# Altium schematic sheet BACKPMAN30_Charger.SchDoc
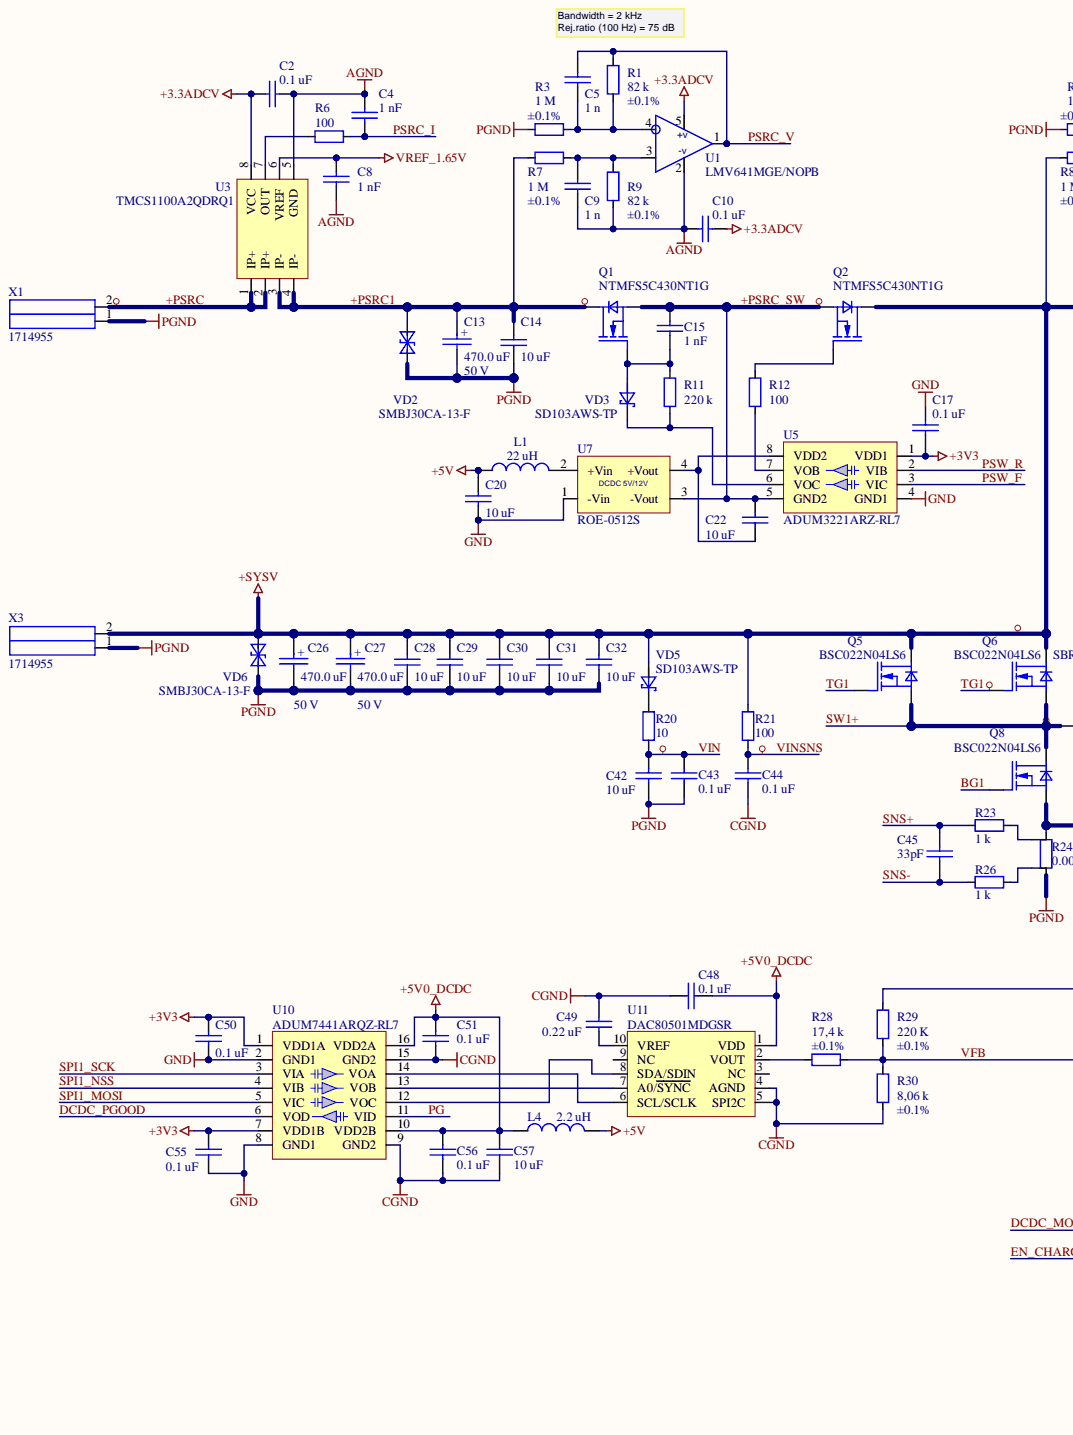
[diagram p0: BACKPMAN30_Charger.SchDoc, left]
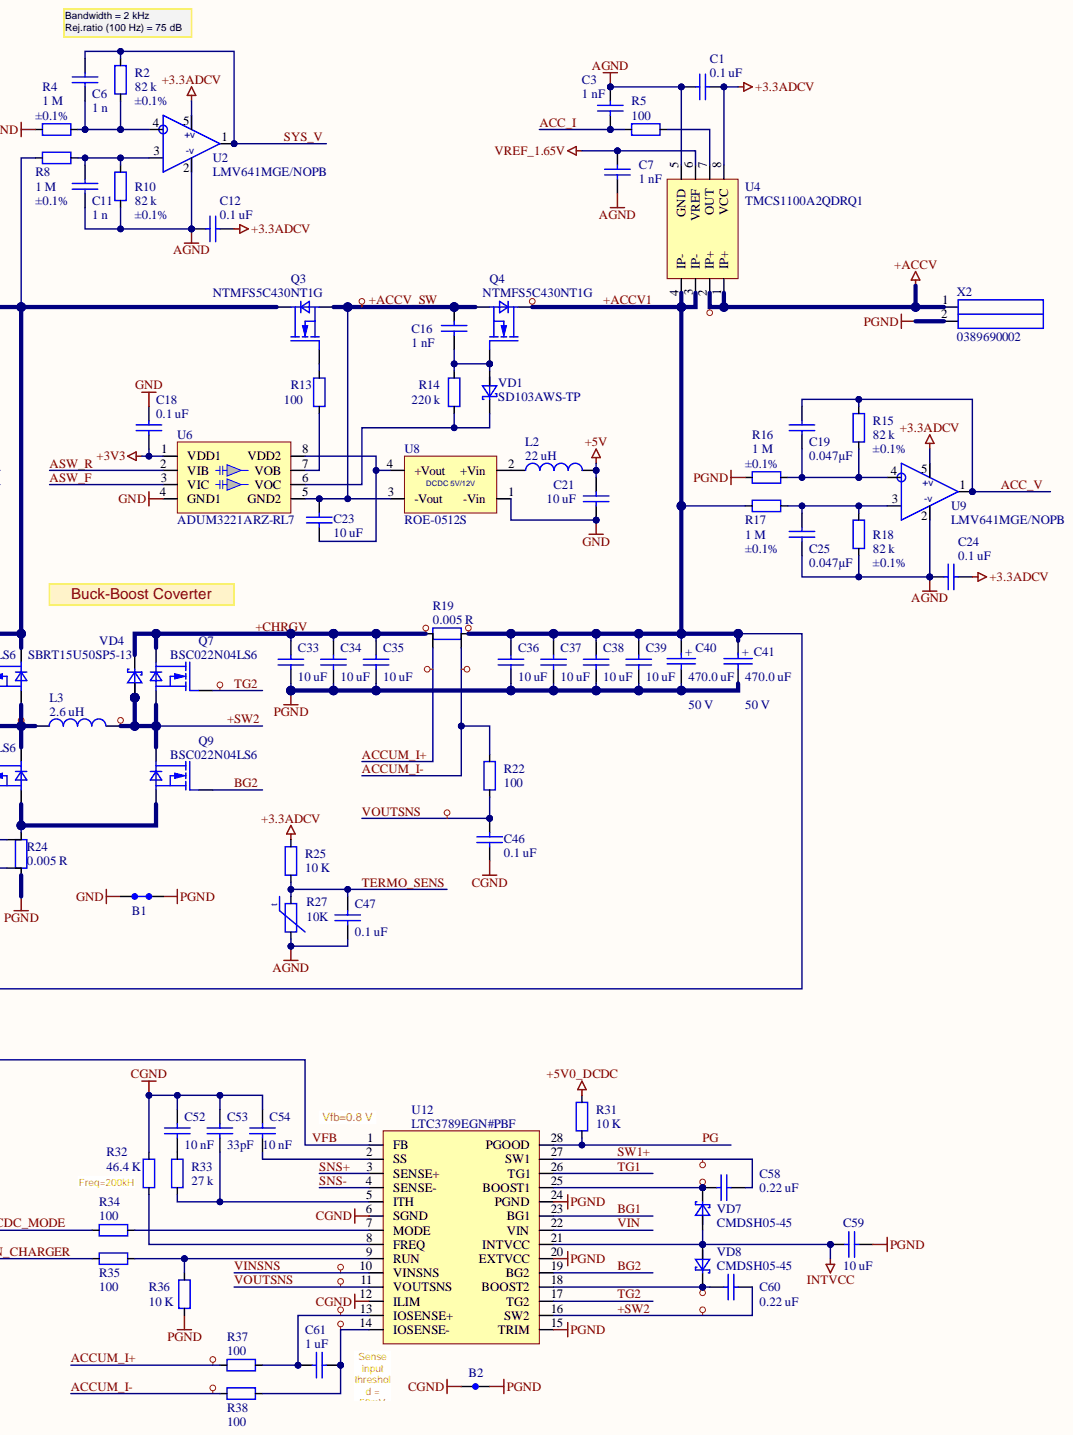
[diagram p1: BACKPMAN30_Charger.SchDoc, right]

## Parts
B1  Net tie W10  [Net tee W 10 mm]  (value not drawn)
B2  Net tie 0.3mm  [Net tee 0.3 mm]  (value not drawn)
C1  value 0.1 uF  [1608[0603]]  (hidden comment: 0603B104K500CT)
C2  value 0.1 uF  [1608[0603]]  (hidden comment: 0603B104K500CT)
C3  value 1 nF  [0603 CAP]  (hidden comment: Capacitor)
C4  value 1 nF  [0603 CAP]  (hidden comment: Capacitor)
C5  value 1 n  [1608[0603]]  (hidden comment: 06033A102FAT2A)
C6  value 1 n  [1608[0603]]  (hidden comment: 06033A102FAT2A)
C7  value 1 nF  [0603 CAP]  (hidden comment: Capacitor)
C8  value 1 nF  [0603 CAP]  (hidden comment: Capacitor)
C9  value 1 n  [1608[0603]]  (hidden comment: 06033A102FAT2A)
C10  value 0.1 uF  [1608[0603]]  (hidden comment: 0603B104K500CT)
C11  value 1 n  [1608[0603]]  (hidden comment: 06033A102FAT2A)
C12  value 0.1 uF  [1608[0603]]  (hidden comment: 0603B104K500CT)
C13  value 470.0 uF  Voltage: 50 V  [Through Hole 12.5/20/5]  (hidden comment: EEU-FM1H471)
C14  value 10 uF  [3225[1210]]  (hidden comment: GMC32X7R106K50NT)
C15  value 1 nF  [0603 CAP]  (hidden comment: Capacitor)
C16  value 1 nF  [0603 CAP]  (hidden comment: Capacitor)
C17  value 0.1 uF  [1608[0603]]  (hidden comment: CL10B104KA8NNND)
C18  value 0.1 uF  [1608[0603]]  (hidden comment: CL10B104KA8NNND)
C19  value 0.047µF  [0603 CAP]  (hidden comment: Capacitor)
C20  value 10 uF  [3225[1210]]  (hidden comment: GMC32X7R106K50NT)
C21  value 10 uF  [3225[1210]]  (hidden comment: GMC32X7R106K50NT)
C22  value 10 uF  [3225[1210]]  (hidden comment: GMC32X7R106K50NT)
C23  value 10 uF  [3225[1210]]  (hidden comment: GMC32X7R106K50NT)
C24  value 0.1 uF  [1608[0603]]  (hidden comment: 0603B104K500CT)
C25  value 0.047µF  [0603 CAP]  (hidden comment: Capacitor)
C26  value 470.0 uF  Voltage: 50 V  [Through Hole 12.5/20/5]  (hidden comment: EEU-FM1H471)
C27  value 470.0 uF  Voltage: 50 V  [Through Hole 12.5/20/5]  (hidden comment: EEU-FM1H471)
C28  value 10 uF  [3225[1210]]  (hidden comment: GMC32X7R106K50NT)
C29  value 10 uF  [3225[1210]]  (hidden comment: GMC32X7R106K50NT)
C30  value 10 uF  [3225[1210]]  (hidden comment: GMC32X7R106K50NT)
C31  value 10 uF  [3225[1210]]  (hidden comment: GMC32X7R106K50NT)
C32  value 10 uF  [3225[1210]]  (hidden comment: GMC32X7R106K50NT)
C33  value 10 uF  [3225[1210]]  (hidden comment: GMC32X7R106K50NT)
C34  value 10 uF  [3225[1210]]  (hidden comment: GMC32X7R106K50NT)
C35  value 10 uF  [3225[1210]]  (hidden comment: GMC32X7R106K50NT)
C36  value 10 uF  [3225[1210]]  (hidden comment: GMC32X7R106K50NT)
C37  value 10 uF  [3225[1210]]  (hidden comment: GMC32X7R106K50NT)
C38  value 10 uF  [3225[1210]]  (hidden comment: GMC32X7R106K50NT)
C39  value 10 uF  [3225[1210]]  (hidden comment: GMC32X7R106K50NT)
C40  value 470.0 uF  Voltage: 50 V  [Through Hole 12.5/20/5]  (hidden comment: EEU-FM1H471)
C41  value 470.0 uF  Voltage: 50 V  [Through Hole 12.5/20/5]  (hidden comment: EEU-FM1H471)
C42  value 10 uF  [3225[1210]]  (hidden comment: GMC32X7R106K50NT)
C43  value 0.1 uF  [1608[0603]]  (hidden comment: 0603B104K500CT)
C44  value 0.1 uF  [1608[0603]]  (hidden comment: 0603B104K500CT)
C45  value 33pF  [0603 CAP]  (hidden comment: Capacitor)
C46  value 0.1 uF  [1608[0603]]  (hidden comment: 0603B104K500CT)
C47  value 0.1 uF  [1608[0603]]  (hidden comment: 0603B104K500CT)
C48  value 0.1 uF  [1608[0603]]  (hidden comment: 0603B104K500CT)
C49  value 0.22 uF  [1608[0603]]  (hidden comment: C1608X7R1H224K080AB)
C50  value 0.1 uF  [1608[0603]]  (hidden comment: CL10B104KA8NNND)
C51  value 0.1 uF  [1608[0603]]  (hidden comment: CL10B104KA8NNND)
C52  value 10 nF  [1608[0603]]  (hidden comment: GMC10X7R103M50NT)
C53  value 33pF  [0603 CAP]  (hidden comment: Capacitor)
C54  value 10 nF  [1608[0603]]  (hidden comment: GMC10X7R103M50NT)
C55  value 0.1 uF  [1608[0603]]  (hidden comment: CL10B104KA8NNND)
C56  value 0.1 uF  [1608[0603]]  (hidden comment: CL10B104KA8NNND)
C57  value 10 uF  [2012[0805]]  (hidden comment: GRM21BR61E106KA73L)
C58  value 0.22 uF  [1608[0603]]  (hidden comment: C1608X7R1H224K080AB)
C59  value 10 uF  [2012[0805]]  (hidden comment: GRM21BR61E106KA73L)
C60  value 0.22 uF  [1608[0603]]  (hidden comment: C1608X7R1H224K080AB)
C61  value 1 uF  [1608[0603]]  (hidden comment: C1608X5R1H105K080AB)
L1  value 22 uH  [2518]  (hidden comment: BRC2518T220K)
L2  value 22 uH  [2518]  (hidden comment: BRC2518T220K)
L3  value 2.6 uH  [7443556260   Wurth Elektronik]  (hidden comment: 7443556260)
L4  value 2.2 uH  [LSQPB3225]  (hidden comment: BRL3225T2R2M)
Q1  NTMFS5C430NT1G  [SO8FL Universal Footprint]
Q2  NTMFS5C430NT1G  [SO8FL Universal Footprint]
Q3  NTMFS5C430NT1G  [SO8FL Universal Footprint]
Q4  NTMFS5C430NT1G  [SO8FL Universal Footprint]
Q5  BSC022N04LS6  [SO8FL Universal Footprint]
Q6  BSC022N04LS6  [SO8FL Universal Footprint]
Q7  BSC022N04LS6  [SO8FL Universal Footprint]
Q8  BSC022N04LS6  [SO8FL Universal Footprint]
Q9  BSC022N04LS6  [SO8FL Universal Footprint]
R1  value 82 k  [0603]  (hidden comment: Resistor)
R2  value 82 k  [0603]  (hidden comment: Resistor)
R3  value 1 M  Tolerance: ±0.1%  [0805]  (hidden comment: RT0805BRE071ML)
R4  value 1 M  Tolerance: ±0.1%  [0805]  (hidden comment: RT0805BRE071ML)
R5  value 100  [0603]  (hidden comment: Resistor)
R6  value 100  [0603]  (hidden comment: Resistor)
R7  value 1 M  [0805]  (hidden comment: RT0805BRE071ML)
R8  value 1 M  [0805]  (hidden comment: RT0805BRE071ML)
R9  value 82 k  Tolerance: ±0.1%  [0603]  (hidden comment: Resistor)
R10  value 82 k  Tolerance: ±0.1%  [0603]  (hidden comment: Resistor)
R11  value 220 k  [0603]  (hidden comment: Resistor)
R12  value 100  [0603]  (hidden comment: Resistor)
R13  value 100  [0603]  (hidden comment: Resistor)
R14  value 220 k  [0603]  (hidden comment: Resistor)
R15  value 82 k  [0603]  (hidden comment: Resistor)
R16  value 1 M  Tolerance: ±0.1%  [0805]  (hidden comment: RT0805BRE071ML)
R17  value 1 M  [0805]  (hidden comment: RT0805BRE071ML)
R18  value 82 k  Tolerance: ±0.1%  [0603]  (hidden comment: Resistor)
R19  value 0.005 R  [FC4L110]  (hidden comment: FC4L110R005FER)
R20  value 10  [0805]  (hidden comment: Resistor)
R21  value 100  [0603]  (hidden comment: Resistor)
R22  value 100  [0603]  (hidden comment: Resistor)
R23  value 1 k  [0603]  (hidden comment: Resistor)
R24  value 0.005 R  [FC4L110]  (hidden comment: FC4L110R005FER)
R25  value 10 K  [0603]  (hidden comment: RMCF0603FT10K0)
R26  value 1 k  [0603]  (hidden comment: Resistor)
R27  value 10K  [0603]  (hidden comment: NTCG163JX103DTDS)
R28  value 17,4 k  Tolerance: ±0.1%  [0603]  (hidden comment: Resistor)
R29  value 220 K  Tolerance: ±0.1%  [0603]  (hidden comment: TNPW0603220KBEEA)
R30  value 8,06 k  Tolerance: ±0.1%  [0603]  (hidden comment: Resistor)
R31  value 10 K  [0603]  (hidden comment: RMCF0603FT10K0)
R32  value 46.4 K  [0603]  (hidden comment: RC0603FR-0746K4L)
R33  value 27 k  [0603]  (hidden comment: Resistor)
R34  value 100  [0603]  (hidden comment: Resistor)
R35  value 100  [0603]  (hidden comment: Resistor)
R36  value 10 K  [0603]  (hidden comment: RMCF0603FT10K0)
R37  value 100  [0603]  (hidden comment: Resistor)
R38  value 100  [0603]  (hidden comment: Resistor)
U1  LMV641MGE/NOPB  [SOT-353 ON Semi]
U2  LMV641MGE/NOPB  [SOT-353 ON Semi]
U3  TMCS1100A2QDRQ1  [8-SOIC Linear]
U4  TMCS1100A2QDRQ1  [8-SOIC Linear]
U5  ADUM3221ARZ-RL7  [8-SOIC Linear]
U6  ADUM3221ARZ-RL7  [8-SOIC Linear]
U7  ROE-0512S  [Recom 4-SIP module]
U8  ROE-0512S  [Recom 4-SIP module]
U9  LMV641MGE/NOPB  [SOT-353 ON Semi]
U10  ADUM7441ARQZ-RL7  [QSOP-16 AD]
U11  DAC80501MDGSR  [10-Lead Plastic MSOP Linear]
U12  LTC3789EGN#PBF  [28-Lead Plastic SSOP Linear]
VD1  SD103AWS-TP  [SOD323]
VD2  SMBJ30CA-13-F  [DO-214AA_SMBJ BI]
VD3  SD103AWS-TP  [SOD323]
VD4  SBRT15U50SP5-13  [PowerDI 5]
VD5  SD103AWS-TP  [SOD323]
VD6  SMBJ30CA-13-F  [DO-214AA_SMBJ BI]
VD7  PartName: CMDSH05-45  [SOD323]  (hidden comment: CMDSH05-45 TR PBFREE)
VD8  PartName: CMDSH05-45  [SOD323]  (hidden comment: CMDSH05-45 TR PBFREE)
X1  1714955  [MKDS5/2-6.35]
X2  0389690002  [Molex 389690002]
X3  1714955  [MKDS5/2-6.35]

## Nets
+3.3ADCV: C1.2 C2.2 C10.1 C12.1 C24.1 R25.2 U1.5(+v) U2.5(+v) U3.8(VCC) U4.8(VCC) U9.5(+v)
+3V3: C17.1 C18.1 C50.2 C55.2 U5.1(VDD1) U6.1(VDD1) U10.1(VDD1A) U10.7(VDD1B)
+5V: C20.1 C21.1 C48.1 C51.2 C56.2 C57.2 L1.1 L2.1 L4.1 L4.2 R31.1 U10.10(VDD2B) U10.16(VDD2A) U11.1(VDD)
+ACCV: U4.1(IP+) U4.2(IP+) X2.1
+SYSV: C26.1 C27.1 C28.1 C29.1 C30.1 C31.1 C32.1 Q2.3 Q3.3 Q5.3 Q6.3 R8.1 R21.2 VD5.1 VD6.2 X3.2
AGND: C1.1 C2.1 C3.2 C4.2 C7.1 C8.1 C9.2 C10.2 C11.2 C12.2 C24.2 C25.2 C47.2 R9.1 R10.1 R18.1 R27.1 U1.2(-v) U2.2(-v) U3.5(GND) U4.5(GND) U9.2(-v)
CGND: B2.2 C44.2 C46.2 C48.2 C49.1 C51.1 C52.1 C53.1 C54.1 C56.1 C57.1 R30.1 R32.1 U10.9(GND2) U10.15(GND2) U11.4(AGND) U11.5(SPI2C) U12.6(SGND) U12.12(ILIM)
GND: B1.1 C17.2 C18.2 C20.2 C21.2 C50.1 C55.1 U5.4(GND1) U6.4(GND1) U7.1(-Vin) U8.1(-Vin) U10.2(GND1) U10.8(GND1)
INTVCC: C59.1 U12.21(INTVCC) VD7.1 VD8.1
PGND: B1.2 B2.1 C13.2 C14.2 C26.2 C27.2 C28.2 C29.2 C30.2 C31.2 C32.2 C33.2 C34.2 C35.2 C36.2 C37.2 C38.2 C39.2 C40.2 C41.2 C42.2 C43.2 C59.2 R3.1 R4.1 R16.1 R24.2 R36.1 U12.15(TRIM) U12.20(EXTVCC) U12.24(PGND) VD2.1 VD6.1 X1.1 X2.2 X3.1
VREF_1.65V: C7.2 C8.2 U3.6(VREF) U4.6(VREF)
+ACCV1: C36.1 C37.1 C38.1 C39.1 C40.1 C41.1 Q4.3 R17.1 R19.2 R29.2 U4.3(IP-) U4.4(IP-)
+ACCV_SW: C16.2 C23.1 Q3.2 Q4.2 U6.5(GND2) U8.3(-Vout)
+CHRGV: C33.1 C34.1 C35.1 Q7.3 R19.1 VD4.3
+PSRC: U3.1(IP+) U3.2(IP+) X1.2
+PSRC1: C13.1 C14.1 Q1.3 R7.1 U3.3(IP-) U3.4(IP-) VD2.2
+PSRC_SW: C15.2 C22.1 Q1.2 Q2.2 U5.5(GND2) U7.3(-Vout)
+SW2: C60.2 L3.2 Q7.2 Q9.3 U12.16(SW2) VD4.1 VD4.2
ACC_I: C3.1 R5.1
ACC_V: C19.1 R15.2 U9.1
ACCUM_I+: R19.3 R37.2
ACCUM_I-: R19.4 R22.2 R38.2
ASW_F: U6.3(VIC)
ASW_R: U6.2(VIB)
BG1: Q8.1 U12.23(BG1)
BG2: Q9.1 U12.19(BG2)
DCDC_MODE: R34.2
DCDC_PGOOD: U10.6(VOD)
EN_CHARGER: R35.2
PG: R31.2 U10.11(VID) U12.28(PGOOD)
PSRC_I: C4.1 R6.1
PSRC_V: C5.1 R1.2 U1.1
PSW_F: U5.3(VIC)
PSW_R: U5.2(VIB)
SNS+: C45.1 R23.2 U12.3(SENSE+)
SNS-: C45.2 R26.2 U12.4(SENSE-)
SPI1_MOSI: U10.5(VIC)
SPI1_NSS: U10.4(VIB)
SPI1_SCK: U10.3(VIA)
SW1+: C58.2 L3.1 Q5.2 Q6.2 Q8.3 U12.27(SW1)
SYS_V: C6.1 R2.2 U2.1
TERMO_SENS: C47.1 R25.1 R27.2
TG1: Q5.1 Q6.1 U12.26(TG1)
TG2: Q7.1 U12.17(TG2)
VFB: R28.2 R29.1 R30.2 U12.1(FB)
VIN: C42.1 C43.1 R20.1 U12.22(VIN)
VINSNS: C44.1 R21.1 U12.10(VINSNS)
VOUTSNS: C46.1 R22.1 U12.11(VOUTSNS)
NetC5_2: C5.2 R1.1 R3.2 U1.4
NetC6_2: C6.2 R2.1 R4.2 U2.4
NetC9_1: C9.1 R7.2 R9.2 U1.3
NetC11_1: C11.1 R8.2 R10.2 U2.3
NetC15_1: C15.1 Q1.1 R11.2 VD3.1
NetC16_1: C16.1 Q4.1 R14.2 VD1.1
NetC19_2: C19.2 R15.1 R16.2 U9.4
NetC22_2: C22.2 U5.8(VDD2) U7.4(+Vout)
NetC23_2: C23.2 U6.8(VDD2) U8.4(+Vout)
NetC25_1: C25.1 R17.2 R18.2 U9.3
NetC49_2: C49.2 U11.10(VREF)
NetC52_2: C52.2 R33.2
NetC53_2: C53.2 R33.1 U12.5(ITH)
NetC54_2: C54.2 U12.2(SS)
NetC58_1: C58.1 U12.25(BOOST1) VD7.2
NetC60_1: C60.1 U12.18(BOOST2) VD8.2
NetC61_1: C61.1 R38.1 U12.14(IOSENSE-)
NetC61_2: C61.2 R37.1 U12.13(IOSENSE+)
NetL1_2: L1.2 U7.2(+Vin)
NetL2_2: L2.2 U8.2(+Vin)
NetQ2_1: Q2.1 R12.2
NetQ3_1: Q3.1 R13.2
NetQ8_2: Q8.2 Q9.2 R24.1
NetR5_2: R5.2 U4.7(OUT)
NetR6_2: R6.2 U3.7(OUT)
NetR11_1: R11.1 U5.6(VOC) VD3.2
NetR12_1: R12.1 U5.7(VOB)
NetR13_1: R13.1 U6.7(VOB)
NetR14_1: R14.1 U6.6(VOC) VD1.2
NetR20_2: R20.2 VD5.2
NetR23_1: R23.1 R24.3
NetR24_4: R24.4 R26.1
NetR28_1: R28.1 U11.2(VOUT)
NetR32_2: R32.2 U12.8(FREQ)
NetR34_1: R34.1 U12.7(MODE)
NetR35_1: R35.1 R36.2 U12.9(RUN)
NetU10_12: U10.12(VOC) U11.8(SDA/SDIN)
NetU10_13: U10.13(VOB) U11.7(A0/SYNC)
NetU10_14: U10.14(VOA) U11.6(SCL/SCLK)

Not connected: U11 3(NC) 9(NC)

## Library data of the parts
B1 = Net tie W10: Net tie width 10 mm; Component Kind: Net Tie; Library Name: ea_Mechanical.SchLib
C1 C2 C10 C12 C17 C18 C24 C43 C44 C46-C48 C51 C55 = 0603B104K500CT: 0.1 µF ±10% 50V Ceramic Capacitor X7R 0603 (1608 Metric), -55°C ~ 125°C; Manufacturer: Walsin Technology Corporation; PartNum: 1292-1643-1-ND; Tolerance: +-10%; Component Kind: Standard; Distributor: Digi-Key; PartName: 0603B104K500CT; Price: 0.0062 Euro; Voltage: 50V; Library Name: ea_Capacitors_0603.SCHLIB
C3 C4 C7 C8 C15 C16 = CC0603JRX7R9BB102: 1000pF, ±5%, 50V, X7R, 0603 (1608 Metric), -55°C ~ 125°C, -, General Purpose; Manufacturer: Yageo; PartNum: 311-3361-1-ND; Database Table Name: Cap_0603_50V; Component Kind: Standard; Distributor: Digi-Key; Features: -; Operating Temperature: -55°C ~ 125°C; Package / Case: 0603 (1608 Metric); Part Number: CC0603JRX7R9BB102; PartName: CC0603JRX7R9BB102; Price: 0.01920; Temperature Coefficient: X7R; Tolerance: ±5%; Voltage - Rated: 50V; Library Name: ea_PassivComps.DbLib
C5 C6 C9 C11 = 06033A102FAT2A: 1000 pF ±1% 25V Ceramic Capacitor C0G, NP0 0603 (1608 Metric), -55°C ~ 125°C; Manufacturer: AVX Corporation; PartNum: 478-7896-1-ND; Component Kind: Standard; Distributor: DigiKey; PartName: 06033A102FAT2A; Price: 0.2$; Tolerance: ±1%; Voltage: 25 V; Library Name: ea_Capacitors_0603.SCHLIB
C13 C26 C27 C40 C41 = EEU-FM1H471: 470.0uF, 50V, Aluminium Electrolytic Capacitors, Diam. 12.5mm, Lead sp. 5 mm, Length 22mm, 2.26 mA, 22 mOhms, 5000 Hour, -40°C...105°C; Manufacturer: Panasonic Electronic Components; PartNum: P12400-ND; Tolerance: +-20%; Component Kind: Standard; Distributor: Digi-Key; Library Name: ea_Capacitors_ThroughHole.SCHLIB; PartName: EEU-FM1H471; Price: 0.52$
  C13 C26: Value: 470.0 uF
C14 C20-C23 C28-C32 C34 C35 C37-C39 C42 = GMC32X7R106K50NT: 10 µF ±10%, 50V, Ceramic Capacitor X7R, 1210 (3225 Metric), -55°C ~ 125°C; Manufacturer: CAL-CHIP ELECTRONICS, INC.; PartNum: 2571-GMC32X7R106K50NTTR-ND; Component Kind: Standard; Distributor: Digi-Key; PartName: GMC32X7R106K50NT; Tolerance: ±10%; Voltage: 50V; Library Name: ea_Capacitors_1210.SCHLIB
C19 C25 = CC0603JRX7R9BB473: 0.047µF, ±5%, 50V, X7R, 0603 (1608 Metric), -55°C ~ 125°C, -, General Purpose; Manufacturer: Yageo; PartNum: 311-4019-1-ND; Database Table Name: Cap_0603_50V; Component Kind: Standard; Distributor: Digi-Key; Features: -; Operating Temperature: -55°C ~ 125°C; Package / Case: 0603 (1608 Metric); Part Number: CC0603JRX7R9BB473; PartName: CC0603JRX7R9BB473; Price: 0.03660; Temperature Coefficient: X7R; Tolerance: ±5%; Voltage - Rated: 50V; Library Name: ea_PassivComps.DbLib
C33 C36 = GMC32X7R106K50NT: 10 µF ±10%, 50V, Ceramic Capacitor X7R, 1210 (3225 Metric), -55°C ~ 125°C; Manufacturer: CAL-CHIP ELECTRONICS, INC.; PartNum: 2571-GMC32X7R106K50NTTR-ND; Value: 10 uF; Component Kind: Standard; Distributor: Digi-Key; PartName: GMC32X7R106K50NT; Tolerance: ±10%; Voltage: 50V; Library Name: ea_Capacitors_1210.SCHLIB
C45 C53 = 885012006054: 33pF, ±5%, 50V, C0G, NP0, 0603 (1608 Metric), -55°C ~ 125°C, -, General Purpose; Manufacturer: Wurth Electronics Inc.; PartNum: 732-7796-1-ND; Database Table Name: Cap_0603_50V; Component Kind: Standard; Distributor: Digi-Key; Features: -; Operating Temperature: -55°C ~ 125°C; Package / Case: 0603 (1608 Metric); Part Number: 885012006054; PartName: 885012006054; Price: 0.02150; Temperature Coefficient: C0G, NP0; Tolerance: ±5%; Voltage - Rated: 50V; Library Name: ea_PassivComps.DbLib
C49 C58 C60 = C1608X7R1H224K080AB: 0.22 uF, ±10% 50V Ceramic Capacitor X7R, Low ESL 0603 (1608 Metric), -55°C ~ 125°C; Manufacturer: TDK Corporation; PartNum: 445-7408-1-ND; Tolerance: +-10%; Component Kind: Standard; Distributor: Digi-Key; PartName: C1608X7R1H224K080AB; Price: 0.0062 Euro; Voltage: 50 V; Library Name: ea_Capacitors_0603.SCHLIB
C50 C56 = 0603B104K500CT: 0.1 µF ±10% 50V Ceramic Capacitor X7R 0603 (1608 Metric), -55°C ~ 125°C; Manufacturer: Walsin Technology Corporation; PartNum: 1292-1643-1-ND; Tolerance: +-10%; Value: 0.1 uF; Component Kind: Standard; Distributor: Digi-Key; PartName: 0603B104K500CT; Price: 0.0062 Euro; Voltage: 50V; Library Name: ea_Capacitors_0603.SCHLIB
C52 = GMC10X7R103M50NT: 10 nF, ±20%, 50V,  Ceramic Capacitor X7R 0603 (1608 Metric), -55°C ~ 125°C; Manufacturer: CAL-CHIP ELECTRONICS, INC.; PartNum: 2571-GMC10X7R103M50NTTR-ND; Tolerance: +-10%; Value: 10 nF; Component Kind: Standard; Distributor: Digi-Key; PartName: GMC10X7R103M50NT; Price: 0.0047 Euro; Voltage: 50 V; Library Name: ea_Capacitors_0603.SCHLIB
C54 = GMC10X7R103M50NT: 10 nF, ±20%, 50V,  Ceramic Capacitor X7R 0603 (1608 Metric), -55°C ~ 125°C; Manufacturer: CAL-CHIP ELECTRONICS, INC.; PartNum: 2571-GMC10X7R103M50NTTR-ND; Tolerance: +-10%; Component Kind: Standard; Distributor: Digi-Key; PartName: GMC10X7R103M50NT; Price: 0.0047 Euro; Voltage: 50 V; Library Name: ea_Capacitors_0603.SCHLIB
C57 C59 = GRM21BR61E106KA73L: 10 µF ±10%, 25V, Ceramic Capacitor X5R 0805 (2012 Metric),  -55°C ~ 85°C; Manufacturer: Murata Electronics; PartNum: 490-5523-1-ND; Component Kind: Standard; Distributor: Digi-Key; PartName: GRM21BR61E106KA73L; Price: 0.11$; Tolerance: ±10%; Voltage: 25V; Library Name: ea_Capacitors_0805.SCHLIB
C61 = C1608X5R1H105K080AB: 1 uF, ±10%, Low ESL, 50V, Ceramic Capacitor X5R 0603 (1608 Metric), -55°C ~ 85°C; Manufacturer: Venkel; PartNum: 445-7468-1-ND; Component Kind: Standard; Distributor: Digi-Key; PartName: C1608X5R1H105K080AB; Tolerance: ±10%; Voltage: 50V; Library Name: ea_Capacitors_0603.SCHLIB
L1 L2 = BRC2518T220K: 22 µH Unshielded Wirewound Inductor, 490 mA, 560mOhm, 1007 (2518 Metric); Manufacturer: Taiyo Yuden; PartNum: 587-2439-1-ND; Tolerance: ±10%; Component Kind: Standard; Distributor: Digi-Key; PartName: BRC2518T220K; Supplier 1: Digi-Key; Supplier Part Number 1: 587-2439-1-ND; Library Name: ea_Inductors.SCHLIB
L3 = 7443556260: 2.6 uH, 31.5 A, ±20%, 1.58 mOhm, -40°C ~ 125°C; Manufacturer: Würth Elektronik; PartNum: 732-2170-1-ND; Component Kind: Standard; Distributor: Digi-Key; PartName: 7443556260; Price: 5.35$; Tolerance: ±20%; Library Name: ea_Inductors.SCHLIB
L4 = BRL3225T2R2M: Inductors 2.2 uH, 1.6 A, 0.078 Ohms, ±20% -40°C ~ 105°C, 1210 (3225 Metric); Manufacturer: Taiyo Yuden; PartNum: 587-2165-1-ND; Component Kind: Standard; Distributor: Digi-Key; PartName: BRL3225T2R2M; Price: 0.16$; Tolerance: ±20%; Library Name: ea_Inductors.SCHLIB
Q1-Q4 = NTMFS5C430NT1G: MOSFET N-CH, 35A (Ta), 185A (Tc), 40V, Vgs=±20V, 1.7 mOhm, 47nC @ 10V, 5-DFN (5x6), -55°C ~ 175°C; Manufacturer: Texas Instruments; PartNum: NTMFS5C430NT1GOSCT-ND; Component Kind: Standard; Distributor: Digi-Key; PartName: NTMFS5C430NT1G; Price: 2.68$; Library Name: ea_MOSFET_&_drivers.SchLib
Q5-Q9 = BSC022N04LS6ATMA1: MOSFET N-CH, 100 A, 60V, Vgs=±20V, 1.8 mOhm, 41nC @ 10V, 5-DFN (5x6), -55°C ~ 150°C; Manufacturer: Infineon Technologies; PartNum: BSC022N04LS6ATMA1CT-ND; Component Kind: Standard; Distributor: Digi-Key; PartName: BSC022N04LS6ATMA1; Price: 1.33; Supplier 1: Digi-Key; Supplier Part Number 1: BSC022N04LS6ATMA1CT-ND; Library Name: ea_MOSFET_&_drivers.SchLib
R1 R2 R9 R10 R15 R18 = ERA-3AEB823V: 82 kOhms, ±0.1%, 0.1W, 1/10W, Thin Film, ±25ppm/°C, 0603 (1608 Metric), -55°C ~ 155°C, Automotive AEC-Q200; Manufacturer: Panasonic Electronic Components; PartNum: P82KDBCT-ND; Database Table Name: Res_0603_01%_<=25ppm_Thin_Film; Voltage: 75V; Component Kind: Standard; Distributor: Digi-Key; Features: Automotive AEC-Q200; Operating Temperature: -55°C ~ 155°C; Package / Case: 0603 (1608 Metric); Part Number: ERA-3AEB823V; PartName: ERA-3AEB823V; Power (Watts): 0.1W, 1/10W; Price: 0.26; Temperature Coefficient: ±25ppm/°C; Library Name: ea_PassivComps.DbLib
  R1 R2 R15: Tolerance: ±0.1%
R3 R4 R7 R8 R16 R17 = RT0805BRE071ML: 1M, ±0.1%, ±50ppm/°C, 0.125W, 1/8W Chip Resistor 0805 (2012 Metric) - Thin Film,-55°C ~ 155°C; Manufacturer: YAGEO; PartNum: YAG4967CT-ND; Component Kind: Standard; Distributor: Digi-Key; PartName: RT0805BRE071ML; Price: 0.09$; Library Name: ea_Resistors_0805.SchLib
  R7 R8 R17: Tolerance: ±0.1%
R5 R6 R12 R13 R21 R22 R34 R35 R37 R38 = RMCF0603FT100R: 100 Ohms, ±1%, 0.1W, 1/10W, Thick Film, ±100ppm/°C, 0603 (1608 Metric), -55°C ~ 155°C, Automotive AEC-Q200; Manufacturer: Stackpole Electronics Inc.; PartNum: RMCF0603FT100RCT-ND; Database Table Name: Res_0603_1%_100ppm; Voltage: 75V; Component Kind: Standard; Distributor: Digi-Key; Features: Automotive AEC-Q200; Operating Temperature: -55°C ~ 155°C; Package / Case: 0603 (1608 Metric); Part Number: RMCF0603FT100R; PartName: RMCF0603FT100R; Power (Watts): 0.1W, 1/10W; Price: 0.00690; Temperature Coefficient: ±100ppm/°C; Tolerance: ±1%; Library Name: ea_PassivComps.DbLib
R11 R14 = RMCF0603FT220K: 220 kOhms, ±1%, 0.1W, 1/10W, Thick Film, ±100ppm/°C, 0603 (1608 Metric), -55°C ~ 155°C, Automotive AEC-Q200; Manufacturer: Stackpole Electronics Inc.; PartNum: RMCF0603FT220KCT-ND; Database Table Name: Res_0603_1%_100ppm; Voltage: 75V; Component Kind: Standard; Distributor: Digi-Key; Features: Automotive AEC-Q200; Operating Temperature: -55°C ~ 155°C; Package / Case: 0603 (1608 Metric); Part Number: RMCF0603FT220K; PartName: RMCF0603FT220K; Power (Watts): 0.1W, 1/10W; Price: 0.00690; Temperature Coefficient: ±100ppm/°C; Tolerance: ±1%; Library Name: ea_PassivComps.DbLib
R19 R24 = FC4L110R005FER: Curr.sens.res. 0.005 OHM 5W 1% , 4320 (50110 Metric); Manufacturer: Ohmite; PartNum: 588-FC4L110R005FER; Component Kind: Standard; Distributor: Digi-Key; PartName: FC4L110R005FER; Price: 2.42; Tolerance: 1%; Library Name: ea_Resistors.SCHLIB
R20 = RMCF0805FT10R0: 10 Ohms, ±1%, 0.125W, 1/8W, Thick Film, ±100ppm/°C, 0805 (2012 Metric), -55°C ~ 155°C, Automotive AEC-Q200; Manufacturer: Stackpole Electronics Inc.; PartNum: RMCF0805FT10R0CT-ND; Database Table Name: Res_0805_1%_100ppm; Voltage: 150V; Component Kind: Standard; Distributor: Digi-Key; Features: Automotive AEC-Q200; Operating Temperature: -55°C ~ 155°C; Package / Case: 0805 (2012 Metric); Part Number: RMCF0805FT10R0; PartName: RMCF0805FT10R0; Power (Watts): 0.125W, 1/8W; Price: 0.00950; Temperature Coefficient: ±100ppm/°C; Tolerance: ±1%; Library Name: ea_PassivComps.DbLib
R23 R26 = RMCF0603FG1K00: 1 kOhms, ±1%, 0.1W, 1/10W, Thick Film, ±100ppm/°C, 0603 (1608 Metric), -55°C ~ 155°C, Automotive AEC-Q200; Manufacturer: Stackpole Electronics Inc.; PartNum: RMCF0603FG1K00CT-ND; Database Table Name: Res_0603_1%_100ppm; Voltage: 75V; Component Kind: Standard; Distributor: Digi-Key; Features: Automotive AEC-Q200; Operating Temperature: -55°C ~ 155°C; Package / Case: 0603 (1608 Metric); Part Number: RMCF0603FG1K00; PartName: RMCF0603FG1K00; Power (Watts): 0.1W, 1/10W; Price: 0.00690; Temperature Coefficient: ±100ppm/°C; Tolerance: ±1%; Library Name: ea_PassivComps.DbLib
R25 R31 R36 = RMCF0603FT10K0: 10 kOhms ±1%, ±100ppm/°C,  0.1W, 1/10W Chip Resistor 0603 (1608 Metric) Automotive AEC-Q200 Thick Film, -55°C ~ 155°C; Manufacturer: Stackpole Electronics Inc; PartNum: RMCF0603FT10K0CT-ND; Component Kind: Standard; Distributor: Digi-Key; PartName: RMCF0603FT10K0; Price: 0.0017$; Tolerance: 1%; Library Name: ea_Resistors_0603.SchLib
R27 = NTCG163JX103DTDS: NTC Thermistor 10k, B25/50 3380K, ±0.5%,  0603 (1608 Metric), -40°C ~ 125°C; Manufacturer: TDK Corporation; PartNum: 445-174519-1-ND; Component Kind: Standard; Distributor: Digi-Key; PartName: NTCG163JX103DTDS; Price: 0.22; Library Name: ea_TermoSensors.SchLib
R28 = ERA-3AEB1742V: 17,4 kOhms, ±0.1%, 0.1W, 1/10W, Thin Film, ±25ppm/°C, 0603 (1608 Metric), -55°C ~ 155°C, Automotive AEC-Q200; Manufacturer: Panasonic Electronic Components; PartNum: P17.4KDBCT-ND; Database Table Name: Res_0603_01%_<=25ppm_Thin_Film; Voltage: 75V; Component Kind: Standard; Distributor: Digi-Key; Features: Automotive AEC-Q200; Operating Temperature: -55°C ~ 155°C; Package / Case: 0603 (1608 Metric); Part Number: ERA-3AEB1742V; PartName: ERA-3AEB1742V; Power (Watts): 0.1W, 1/10W; Price: 0.26; Temperature Coefficient: ±25ppm/°C; Library Name: ea_PassivComps.DbLib
R29 = TNPW0603220KBEEA: 220 kOhms ±0.1% 0.125W, 1/8W Chip Resistor 0603 (1608 Metric), Thin Film; Manufacturer: Vishay Dale; PartNum: 541-3175-1-ND; Component Kind: Standard; Distributor: Digi-Key; Library Name: ea_Resistors_0603.SchLib; PartName: TNPW0603220KBEEA; Price: 0.11$
R30 = ERA-3AEB8061V: 8,06 kOhms, ±0.1%, 0.1W, 1/10W, Thin Film, ±25ppm/°C, 0603 (1608 Metric), -55°C ~ 155°C, Automotive AEC-Q200; Manufacturer: Panasonic Electronic Components; PartNum: P8.06KDBCT-ND; Database Table Name: Res_0603_01%_<=25ppm_Thin_Film; Voltage: 75V; Component Kind: Standard; Distributor: Digi-Key; Features: Automotive AEC-Q200; Operating Temperature: -55°C ~ 155°C; Package / Case: 0603 (1608 Metric); Part Number: ERA-3AEB8061V; PartName: ERA-3AEB8061V; Power (Watts): 0.1W, 1/10W; Price: 0.26; Temperature Coefficient: ±25ppm/°C; Library Name: ea_PassivComps.DbLib
R32 = RC0603FR-0746K4L: 46.4 kOhms ±1% 0.1W, 1/10W Chip Resistor 0603 (1608 Metric) Moisture Resistant Thick Film; Manufacturer: YAGEO; PartNum: 311-46.4KHRCT-ND; Voltage: 75 V; Component Kind: Standard; Distributor: Digi-Key; PartName: RC0603FR-0746K4L; Price: 0.008 Euro; Tolerance: 1%; Library Name: ea_Resistors_0603.SchLib
R33 = RMCF0603FT27K0: 27 kOhms, ±1%, 0.1W, 1/10W, Thick Film, ±100ppm/°C, 0603 (1608 Metric), -55°C ~ 155°C, Automotive AEC-Q200; Manufacturer: Stackpole Electronics Inc.; PartNum: RMCF0603FT27K0CT-ND; Database Table Name: Res_0603_1%_100ppm; Voltage: 75V; Component Kind: Standard; Distributor: Digi-Key; Features: Automotive AEC-Q200; Operating Temperature: -55°C ~ 155°C; Package / Case: 0603 (1608 Metric); Part Number: RMCF0603FT27K0; PartName: RMCF0603FT27K0; Power (Watts): 0.1W, 1/10W; Price: 0.00690; Temperature Coefficient: ±100ppm/°C; Tolerance: ±1%; Library Name: ea_PassivComps.DbLib
U1 U2 U9 = LMV641MGE/NOPB: OpAmp,  Rail-to-Rail,  10MHz, VSS 2.7V ~ 12V, Vofs 30µV,  SC-70-5, -40°C ~ 125°C; Manufacturer: Texas Instruments; PartNum: LMV641MGE/NOPBCT-ND; Component Kind: Standard; Distributor: Digi-Key; PartName: LMV641MGE/NOPB; Price: 1.8$; Supplier 1: Digi-Key; Supplier Part Number 1: 296-OPA991IDBVRCT-ND; Library Name: ea_IC_OPAmps_AnComparators.SCHLIB
U3 U4 = TMCS1100A2QDRQ1: Current Sensor ±14.5A, VCC=3V,  Hall Effect Bidirectional 8-SOIC (0.154", 3.90mm Width), -40°C ~ 125°C (TA); Manufacturer: Texas Instruments; PartNum: 595-TMCS1100A2QDRQ1; Component Kind: Standard; Distributor: Mouser; Library Name: ea_IC_sensors.SchLib; PartName: TMCS1100A2QDRQ1; Price: 2.5$
U5 U6 = ADUM3221ARZ-RL7: 4A Gate Driver Magnetic Coupling 2500Vrms 2 Channel 8-SOIC, -40°C ~ 125°C; Manufacturer: Analog Devices Inc.; PartNum: ADUM3221ARZ-RL7CT-ND; Component Kind: Standard; Distributor: Digi-Key; PartName: ADUM3221ARZ-RL7; Price: 3.1$; Library Name: ea_IC_Isolated_Interfaces.SCHLIB
U7 U8 = ROE-0512S: Isolated Module DC DC Converter 1 Output 12V - - 84mA 4.5V - 5.5V Input; Manufacturer: Recom Power; PartNum: ROE-0512S-ND; Component Kind: Standard; Distributor: Digi-Key; PartName: ROE-0512S; Price: 2.8$; Supplier 1: Digi-Key; Supplier Part Number 1: ROE-0512S-ND; Library Name: ea_PowerSources.SchLib
U10 = ADUM7441ARQZ-RL7: General Purpose Digital Isolator 1000Vrms 4 Channel 1Mbps 15kV/µs CMTI 16-SSOP (0.154", 3.90mm Width), -40°C ~ 105°C; Manufacturer: Analog Devices Inc.; PartNum: ADUM7441ARQZ-RL7CT-ND; Component Kind: Standard; Distributor: Digi-Key; PartName: ADUM7441ARQZ-RL7; Price: 2.4$; Library Name: ea_IC_Isolated_Interfaces.SCHLIB
U11 = DAC80501MDGSR: 16 Bit Digital to Analog Converter, I²C, SPI, 10-VSSOP,  -40°C ~ 125°C; Manufacturer: Texas Instruments; PartNum: 296-DAC80501MDGSRCT-ND; Component Kind: Standard; Distributor: Digi-Key; Library Name: ea_IC_DAC.SchLib; PartName: DAC80501MDGSR; Price: 6$; Supplier 1: Digi-Key; Supplier Part Number 1: 296-DAC80501MDGSTCT-ND
U12 = LTC3789EGN#PBF: Buck-Boost Regulator Positive Output Step-Up/Step-Down DC-DC Controller IC, Vin 4...38V,  28-SSOP, -40°C ~ 125°C; Manufacturer: Linear Technology/Analog Devices; PartNum: LTC3789EGN#PBF-ND; Component Kind: Standard; Distributor: Digi-Key; Library Name: ea_IC_Power_Reg_Ref_Sw.SCHLIB; PartName: LTC3789EGN#PBF; price: 5.6$; Supplier 1: Digi-Key; Supplier Part Number 1: LTC3789EGN#PBF-ND
VD1 VD3 VD5 = SD103AWS-TP: Diode Schottky 40 V 350mA Surface Mount SOD-323, -65°C ~ 125°C; Manufacturer: Micro Commercial Co; PartNum: SD103AWSTPMSCT-ND; Component Kind: Standard; Distributor: Digi-Key; PartName: SD103AWS-TP; Price: 0.1$; Library Name: ea_Diodes.SCHLIB
VD2 VD6 = SMBJ30CA-13-F: TVS 600W, Vbr=33.3V, Vcl=48.4V,  Bidirectional, SMB, -55°C ~ 150°C; Manufacturer: Diodes Incorporated; PartNum: SMBJ30CA-FDICT-ND; Voltage: 30V; Component Kind: Standard; Distributor: Digi-Key; PartName: SMBJ30CA-13-F; Price: 0.21$; Supplier 1: Digi-Key; Supplier Part Number 1: SMBJ30CA-FDICT-ND; Library Name: ea_TVS_protection.SchLib
VD4 = SBRT15U50SP5-13: Schottky Power Rectifier 15A, 50V, 470mV @ 15A, SMA, -65°C ~ 150°C; Manufacturer: Diodes Incorporated; PartNum: SBRT15U50SP5-13DICT-ND; Component Kind: Standard; Distributor: Digi-Key; PartName: SBRT15U50SP5-13; Price: 0.83$; Library Name: ea_Diodes.SCHLIB
VD7 VD8 = CMDSH05-45 TR PBFREE: Diode Schottky 45 V 500mA Surface Mount SOD-323, -65°C ~ 125°C; Manufacturer: Central Semiconductor Corp; PartNum: 1514-CMDSH05-45TRPBFREECT-ND; Component Kind: Standard; Distributor: Digi-Key; Price: 0.23$; Library Name: ea_Diodes.SCHLIB
X1 X3 = 1714955: TERMINAL BLOCK, 2WAY, 6.35 mm, 32A, 500V; Manufacturer: Phoenix Contact; PartNum: 277-1269-ND; Component Kind: Standard; Distributor: Digi-Key; PartName: 1714955; Price: 1.49 Euro; Library Name: ea_PhoenixContacts.SchLib
X2 = 0389690002: 2 Circuit 0.500" (12.70mm) Barrier Block Connector Screws with Captive Plate, 50A, 600V, 8-20AWG; Manufacturer: Molex; PartNum: WM7469-ND; Component Kind: Standard; Distributor: Digi-Key; PartName: 0389690002; Price: 1.03$; Library Name: ea_Connectors_Power.SCHLIB
B2 = Net tie 0.3mm: Component Kind: Net Tie; Description: Net tie 0.3mm; Library Name: ea_Mechanical.SchLib
